# Altium schematic sheet SH_SFW1_V3.0.SchDot
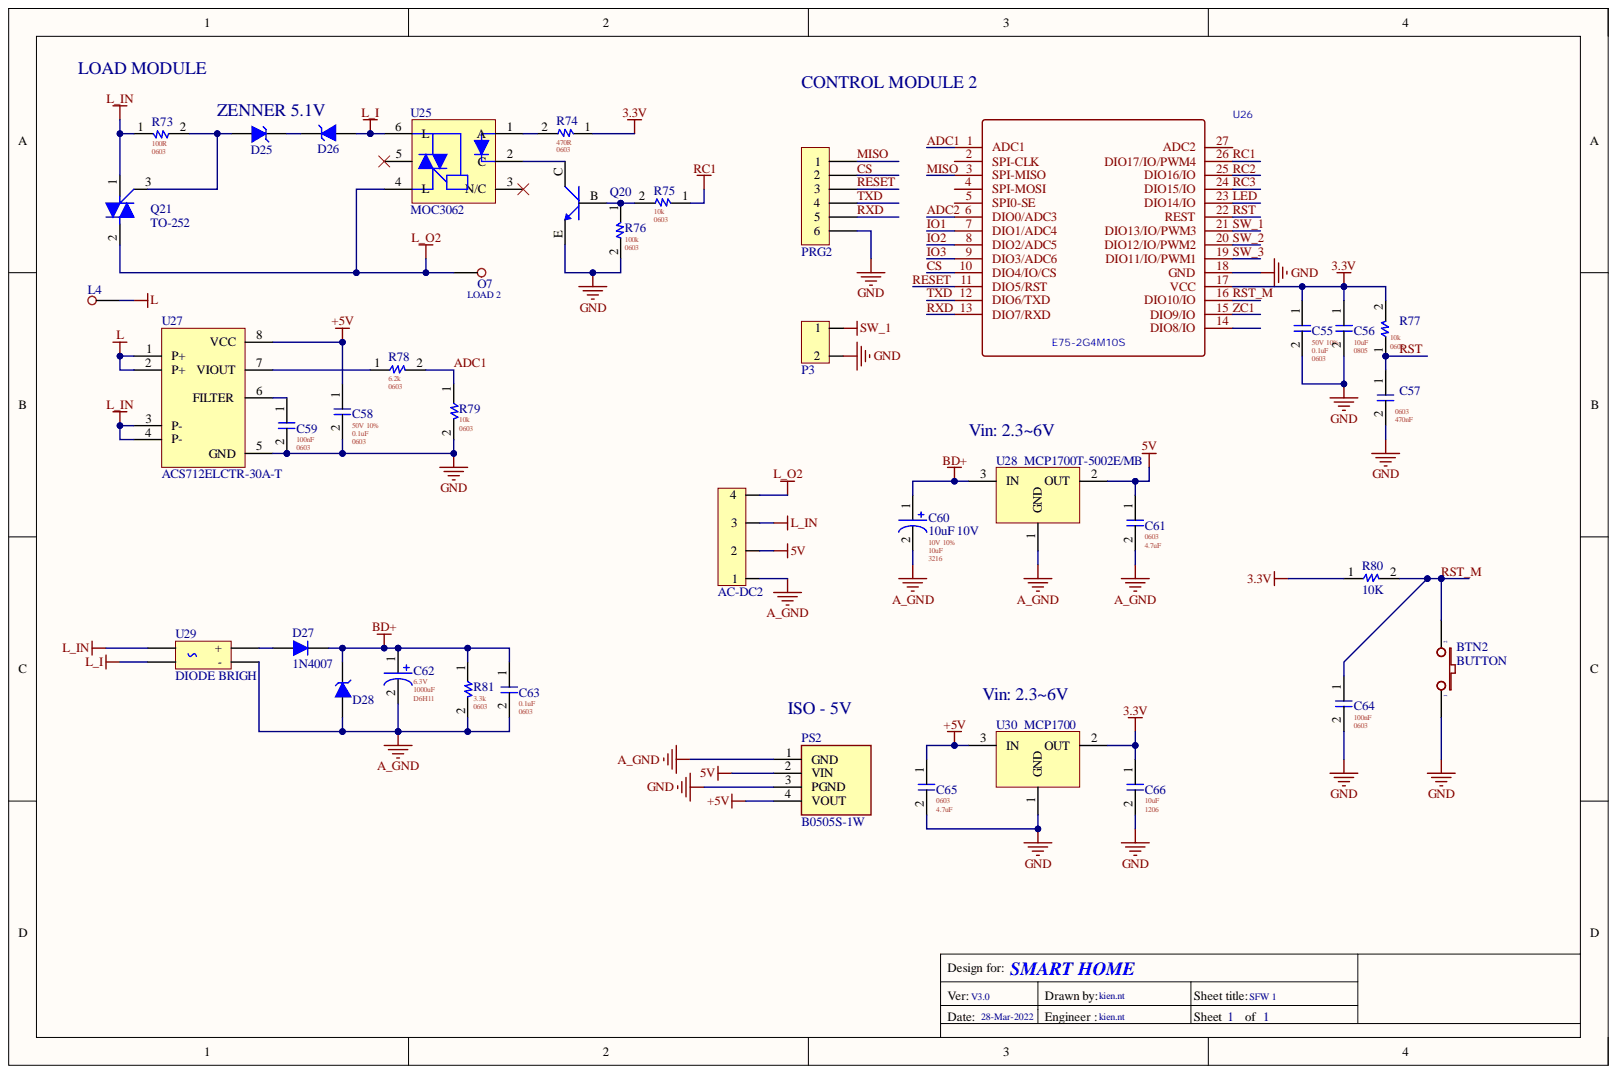
[diagram p0: SH_SFW1_V3.0.SchDot]

## Parts
AC-DC2  P4  [AC-DC 5V K4H24]  (value not drawn)
BTN2  BUTTON  [SW6X3.5mm SMD]
C55  0.1uF  FP: 0603  VOLTAGE + TOLE: 50V 10%  [0603 C]
C56  10uF  FP: 0805  [0805 C]
C57  470nF  FP: 0603  [0603 C]
C58  0.1uF  FP: 0603  VOLTAGE + TOLE: 50V 10%  [0603 C]
C59  value 100nF  FP: 0603  [0603 C]  (hidden comment: 1nF)
C60  10uF 10V  value 10uF  FP: 3216  [CAP-TAN 3216]
C61  4.7uF  FP: 0603  [0603 C]
C62  1000uF 6.3V  value 1000uF  FP: D6H11  VOLTAGE + TOLE: 6.3V  [CAP-AE 6.3x11 DIP2.54]
C63  0.1uF  FP: 0603  [0603 C]
C64  value 100nF  FP: 0603  [0603 C]  (hidden comment: 1nF)
C65  4.7uF  FP: 0603  [0603 C]
C66  10uF  FP: 1206  [1206 C]
D25  5.1V  [SOD-80C]
D26  5.1V  [SOD-80C]
D27  1N4007  [DO214AC]
D28  5.1V  [SOD-80C]  (value not drawn)
L4  P1  [HOLE AC]  (value not drawn)
O7  P1  [HOLE AC]  (value not drawn)
P3  P2  [P2 - 2.54mm]  (value not drawn)
PRG2  P6  [P6 - 1.27MM]  (value not drawn)
PS2  B0505S-1W  [B0505S1W]
Q20  MMBT3904  [SOT 23-3 - BCE]  (value not drawn)
Q21  TO-252  [TO-252]
R73  100R  FP: 0603  [0603 R]
R74  470R  [0603 R]
R75  10k  FP: 0603  [0603 R]
R76  100k  FP: 0603  [0603 R]
R77  10k  FP: 0603  [0603 R]
R78  6.2k  FP: 0603  [0603 R]
R79  10k  FP: 0603  [0603 R]
R80  10K  [0603 R]
R81  3.3k  FP: 0603  [0603 R]
U25  MOC3062  [6-PIN DIP]
U26  E75-2G4M10S  [NXP-E75-10S]
U27  ACS712ELCTR-30A-T  [SOIC 8]
U28  MCP1700T-5002E/MB  [MCP1700]
U29  DIODE BRIGH  [4PIN SOP]
U30  MCP1700  [MCP1700]

## Nets
3.3V: C55.1 C56.1 C66.1 R74.1 R77.2 R80.1 U26.17(VCC) U30.2
5V: AC-DC2.2 C58.1 C61.1 C65.1 PS2.2(VIN) PS2.4(VOUT) U27.8(VCC) U28.2 U30.3
A_GND: AC-DC2.1 C60.2 C61.2 C62.2 C63.2 D28.1 PS2.1(GND) R81.2 U28.1 U29.2(-)
BD+: C60.1 C62.1 C63.1 D27.2 D28.2 R81.1 U28.3
GND: BTN2.1 C55.2 C56.2 C57.2 C58.2 C59.2 C64.2 C65.2 C66.2 P3.2 PRG2.6 PS2.3(PGND) Q20.E R76.2 R79.2 U26.18(GND) U27.5(GND) U30.1
L: L4.1 U27.1(P+) U27.2(P+)
L_I: D26.1 U25.6(L) U29.4
L_IN: AC-DC2.3 Q21.1 R73.1 U27.3(P-) U27.4(P-) U29.3
L_O2: AC-DC2.4 O7.1 Q21.2 U25.4(L)
ADC1: R78.2 R79.1 U26.1(ADC1)
ADC2: U26.6(DIO0/ADC3)
CS: PRG2.2 U26.10(DIO4/IO/CS)
IO1: U26.7(DIO1/ADC4)
IO2: U26.8(DIO2/ADC5)
IO3: U26.9(DIO3/ADC6)
LED: U26.23(DIO14/IO)
MISO: PRG2.1 U26.3(SPI-MISO)
RC1: R75.1 U26.26(DIO17/IO/PWM4)
RC2: U26.25(DIO16/IO)
RC3: U26.24(DIO15/IO)
RESET: PRG2.3 U26.11(DIO5/RST)
RST: C57.1 R77.1 U26.22(REST)
RST_M: BTN2.2 C64.1 R80.2 U26.16(DIO10/IO)
RXD: PRG2.5 U26.13(DIO7/RXD)
SW_1: P3.1 U26.21(DIO13/IO/PWM3)
SW_2: U26.20(DIO12/IO/PWM2)
SW_3: U26.19(DIO11/IO/PWM1)
TXD: PRG2.4 U26.12(DIO6/TXD)
ZC1: U26.15(DIO9/IO)
NetC59_1: C59.1 U27.6(FILTER)
NetD25_1: D25.1 Q21.3 R73.2
NetD25_2: D25.2 D26.2
NetD27_1: D27.1 U29.1(+)
NetQ20_B: Q20.B R75.2 R76.1
NetQ20_C: Q20.C U25.2(C)
NetR74_2: R74.2 U25.1(A)
NetR78_1: R78.1 U27.7(VIOUT)

Not connected: U25 3(N/C) 5; U26 2(SPI-CLK) 4(SPI-MOSI) 5(SPI0-SE) 14(DIO8/IO) 27(ADC2)

## Library data of the parts
C55 C58 = 0603 0.1uF CER: CAP CER 0.1uF 50V 10% 0603 SMD; Component Kind: Standard; ComponentLink1Description: New link; DATE: 04-Mar-21; Library Name: Capacitor.SchLib; PACKAGE: SMD; VALUE: 0.1uF; CREATOR: MMUOP
C56 = 0805 10uF CER: CAP CER 10uF 50V 10% 0805 SMD; VOLTAGE + TOLE: 50V 10%; Component Kind: Standard; ComponentLink1Description: New link; DATE: 04-Mar-21; Library Name: Capacitor.SchLib; PACKAGE: SMD; VALUE: 10uF; CREATOR: MMUOP
C57 = 0603 470nF CER: CAP CER 470nF 50V 10% 0603 SMD; VOLTAGE + TOLE: 50V 10%; Component Kind: Standard; ComponentLink1Description: New link; DATE: 04-Mar-21; Library Name: Capacitor.SchLib; PACKAGE: SMD; VALUE: 470nF; CREATOR: MMUOP
C59 C64 = 0603 1nF CER: CAP CER 1nF 50V 10% 0603 SMD; VOLTAGE + TOLE: 50V 10%; Component Kind: Standard; ComponentLink1Description: New link; DATE: 04-Mar-21; Library Name: Capacitor.SchLib; PACKAGE: SMD; CREATOR: MMUOP
C60 = CAP-TAN 3216 SMD_2: CAP-TAN 10uF 10V 10% 3216 SMD; VOLTAGE + TOLE: 10V 10%; Component Kind: Standard; ComponentLink1Description: New link; ComponentLink1URL: https://www.thegioiic.com/products/tu-tantalum-10uf-10v-1206-taja106k010rnj; DATE: 04-Mar-21; Library Name: Capacitor.SchLib; PACKAGE: SMD; CREATOR: MMUOP
C61 C65 = 0603 4.7uF CER: CAP CER 4.7uF 50V 10% 0603 SMD; VOLTAGE + TOLE: 50V 10%; Component Kind: Standard; ComponentLink1Description: New link; DATE: 04-Mar-21; Library Name: Capacitor.SchLib; PACKAGE: SMD; VALUE: 4.7uF; CREATOR: MMUOP
C62 = CAP-AE 6.3X11mm TH: CAP AE 1000uF 6.3V 20%  D6.3 H11 TH; Component Kind: Standard; ComponentLink1Description: New link; DATE: 04-Mar-21; Library Name: Capacitor.SchLib; PACKAGE: TH; CREATOR: MMUOP
C63 = 0603 0.1uF CER: CAP CER 0.1uF 50V 10% 0603 SMD; VOLTAGE + TOLE: 50V 10%; Component Kind: Standard; ComponentLink1Description: New link; DATE: 04-Mar-21; Library Name: Capacitor.SchLib; PACKAGE: SMD; VALUE: 0.1uF; CREATOR: MMUOP
C66 = 1206 10uF CER: CAP CER 10uF 50V 10% 0805 SMD; VOLTAGE + TOLE: 50V 10%; Component Kind: Standard; ComponentLink1Description: New link; DATE: 04-Mar-21; Library Name: Capacitor.SchLib; PACKAGE: SMD; VALUE: 10uF; CREATOR: MMUOP
D25 D26 D28 = Diode Zener: DIODE ZENER 5.1V 1W; Editor: MMUOP; Component Kind: Standard; Library Name: Kienlib.SchLib
D27 = Diode schottky: Component Kind: Standard; Library Name: Kienlib.SchLib
PS2 = B0505S-1W: Power Supply; Manufacturer_Name: Mornsun; Component Kind: Standard; Height: 10.41mm; Library Name: SamacSys.SchLib; Manufacturer_Part_Number: B0505S-1W
Q20 = TRANS NPN_BCE: Component Kind: Standard; Library Name: Kienlib.SchLib
Q21 = TRIAC: Component Kind: Standard; Library Name: Kienlib.SchLib
R73 = 0603 100R 1%: 100R 1% 0603 SMD; Component Kind: Standard; ComponentLink1Description: New link; DATE: 04-Mar-21; Library Name: Res.SchLib; PACKAGE: SMD; VALUE: 100R; VOLTAGE + TOLE: 1%; CREATOR: MMUOP
R74 = 0603 470R 1%: 470R 1% 0603 SMD; FP: 0603; Component Kind: Standard; ComponentLink1Description: New link; DATE: 04-Mar-21; Library Name: Res.SchLib; PACKAGE: SMD; VALUE: 470R; VOLTAGE + TOLE: 1%; CREATOR: MMUOP
R75 R77 R79 = 0603 10k 1%: 10k 1% 0603 SMD; PN: C98220; Component Kind: Standard; ComponentLink1Description: New link; DATE: 04-Mar-21; Library Name: Res.SchLib; PACKAGE: SMD; VALUE: 10k; VOLTAGE + TOLE: 1%; CREATOR: MMUOP
R76 = 0603 100k 1%: 100k 1% 0603 SMD; Component Kind: Standard; ComponentLink1Description: New link; DATE: 04-Mar-21; Library Name: Res.SchLib; PACKAGE: SMD; VALUE: 100k; VOLTAGE + TOLE: 1%; CREATOR: MMUOP
R78 = 0603 6.2k 1%: 6.2k 1% 0603 SMD; Component Kind: Standard; ComponentLink1Description: New link; DATE: 04-Mar-21; Library Name: Res.SchLib; PACKAGE: SMD; VALUE: 6.2k; VOLTAGE + TOLE: 1%; CREATOR: MMUOP
R80 = Res SMD: RES SMD 1K OHM 1% 0.15W 0603; Component Kind: Standard; Library Name: Kienlib.SchLib
R81 = 0603 3.3k 1%: 3.3k 1% 0603 SMD; Component Kind: Standard; ComponentLink1Description: New link; DATE: 04-Mar-21; Library Name: Res.SchLib; PACKAGE: SMD; VALUE: 3.3k; VOLTAGE + TOLE: 1%; CREATOR: MMUOP
U25 = TRIAC & SCR: Component Kind: Standard; Library Name: Kienlib.SchLib
U27 = ACS71240: ACS712ELCTR-20A  SOIC 8; Component Kind: Standard; ComponentLink1Description: New link; ComponentLink1URL: https://banlinhkiendientu.vn/acs712t/; Library Name: Kienlib.SchLib
U28 = MCP1700: Component Kind: Standard; Library Name: Kienlib.SchLib
AC-DC2 = P4: Component Kind: Standard; Library Name: Kienlib.SchLib
BTN2 = BUTTON: Component Kind: Standard; Library Name: Library V1.0.IntLib
L4 O7 = P1: Component Kind: Standard; Library Name: Kienlib.SchLib
P3 = P2: Component Kind: Standard; Library Name: Kienlib.SchLib
PRG2 = P6: Component Kind: Standard; Library Name: Kienlib.SchLib
U26 = E75-2G4M10S: Component Kind: Standard
U29 = DIODE BRIGH: Component Kind: Standard; Library Name: Kienlib.SchLib
U30 = MCP1700: Component Kind: Standard; Library Name: Kienlib.SchLib
